# Altium schematic sheet PAGE1-MCU,USB,ADC.SchDoc("PAGE1-MCU,USB,ADC") (continued)

## Library data of the parts
C1 C4 C8 = 100nF: MURATA - GRM188R71C104KA01J - CAP, MLCC, X7R, 0.1UF, 16V, 0603; Voltage Rating: 16; SVHC: No SVHC (20-Jun-2016; Product Range: GRM Series; Dielectric Characteristic: X7; Ceramic Capacitor Case: 0603 [1608 Metric]; Capacitance Tolerance: ± 10%; Capacitance: 0.1; Supplier Part Number 1: 2688519; Supplier 1: Farnell; Stock 1: 18297; RoHS: YES; Pricing 1: 10=0.0145, 100=0.0126, 500=0.0081, 2500=0.0059, 5000=0.0052, 10000=0.0046, 50000=0.0036, 100000=0.0035 (GBP); Packaging: Cut Tape; Operating Temperature Min: -55; Operating Temperature Max: 125; MSL: MSL 1 - Unlimited; Manufacturer Part Number: GRM188R71C104KA01J; Manufacturer: MURATA; Library Name: Capacitors.IntLib; ComponentLink1URL: http://www.farnell.com/datasheets/2189124.pdf; ComponentLink1Description: http://www.farnell.com/datasheets/2189124.pdf; Component Kind: Standard; Automotive Qualification Standard: -
C2 = 4u7  16V 0603: MURATA - GRM188R61C475KAAJD - CAP, MLCC, X5R, 4.7UF, 16V, 0603; Voltage Rating: 16; SVHC: No SVHC (17-Dec-2015)<!--FANDB--><div class="features-and-benefits-container"><div class="features-and-benefits-desc-container"><span class="features-and-benefits-container-desc">SMD Multilayer Ceramic Capacitor, 0603 [1608 Metric], 4.7 µF, 16 V, ± 10%, X5; Product Range: GRM Series; Dielectric Characteristic: X5; Ceramic Capacitor Case: 0603 [1608 Metric]; Capacitance Tolerance: ± 10%; Capacitance: 4.7; Supplier Part Number 1: 2611924; Supplier 1: Farnell; Stock 1: 5038; RoHS: YES; Pricing 1: 10=0.16, 100=0.0929, 500=0.076, 1000=0.0618, 2000=0.0605, 4000=0.0425, 20000=0.0409, 40000=0.0355 (GBP); Packaging: Cut Tape; Operating Temperature Min: -55; Operating Temperature Max: 85; MSL: MSL 1 - Unlimited; Manufacturer Part Number: GRM188R61C475KAAJD; Manufacturer: MURATA; Library Name: Capacitors.IntLib; ComponentLink1URL: http://www.farnell.com/datasheets/2079168.pdf; ComponentLink1Description: http://www.farnell.com/datasheets/2079168.pdf; Component Kind: Standard; Automotive Qualification Standard: -
C3 = 10nF: Cap Ceramic 0.01uF 50V X7R 20% SMD 0805 125C Paper T/R; Manufacturer Part Number: C0805C103M5RAC7800; Manufacturer: KEMET; Voltage: 50 Vdc; Rad Hard: No; Product Length: 2 mm; Product Height: 0.78 mm; Product Depth: 1.25 mm; Tolerance: 20 %; Supplier Part Number 1: 2581081; Supplier 1: Farnell; Package/Case: 0805; Operating Temperature: -55 to 125 °C; Mounting: Surface Mount; Dielectric: X7R; Construction: Flat; ComponentLink1URL: http://capacitoredge.kemet.com/capedge2/DataSheet?pn=C0805C103M5RACTU; ComponentLink1Description: http://capacitoredge.kemet.com/capedge2/DataSheet?pn=C0805C103M5RACTU; Component Kind: Standard; Category: Capacitor Ceramic Multilayer; Capacitance Value: 0.01 uF; Library Name: RPi-DAC-schlib.SchLib
C5 = 10uF /16V: TDK - CGA4J1X5R1C106K125AC - CAP, MLCC, X5R, 10UF, 16V, 0805; Supplier Part Number 1: 2210948RL; Supplier 1: Farnell; Stock 1: 8974; RoHS: YES; Pricing 1: 5=0.41, 50=0.146, 250=0.131, 500=0.12, 1000=0.103 (GBP); Manufacturer Part Number: CGA4J1X5R1C106K125AC; Manufacturer: TDK; Library Name: Capacitors.IntLib; ComponentLink3URL: http://www.farnell.com/datasheets/1683658.pdf; ComponentLink3Description: http://www.farnell.com/datasheets/1683658.pdf; ComponentLink2URL: http://www.farnell.com/datasheets/1936565.pdf; ComponentLink2Description: http://www.farnell.com/datasheets/1936565.pdf; ComponentLink1URL: http://bcove.me/e1v54mtm; ComponentLink1Description: http://bcove.me/e1v54mtm; Component Kind: Standard
C6 = 22u 6.3V 0805: MULTICOMP - MC0805X226M6R3CT - CAP, MLCC, X5R, 22UF, 0805; Voltage Rating: 6.3; SVHC: No SVHC (07-Jul-2017); Product Range: MC Series; Dielectric Characteristic: X5; Ceramic Capacitor Case: 0805 [2012 Metric]; Capacitor Terminals: SMD; Capacitance Tolerance ±: ± 20%; Capacitance: 22; Supplier Part Number 1: 1759415; Supplier 1: Farnell; Stock 1: 74736; RoHS: YES; Pricing 1: 5=0.128, 50=0.0738, 250=0.0507, 500=0.042, 1500=0.0358 (GBP); Packaging: Cut Tape; Operating Temperature Range: -55°C to +85°C<!--FANDB--><div class="features-and-benefits-container"><div class="features-and-benefits-desc-container"><span class="features-and-benefits-container-desc">SMD Multilayer Ceramic Capacitor, 0805 [2012 Metric], 22 µF, 6.3 V, ± 20%, X5R, MC Series</span></div><div class="features-and-benefits-description"><span class="features-benefits-value">The Multicomp MC series is general purpose MLCC capacitors with X5R dielectric material which provides high electrical precision, stability and reliability. This MLCC capacitor consists of a conducting material and electrodes with high density and high efficiency ceramic condensers.</span></div><div class="features-and-benefits-list"><ul class="features-and-benefits-list-list"><li class="features-and-benefits-list-item">Small size with higher capacitance values</li><li class="features-and-benefits-list-item">0201, 0402, 0603, 0805, 1206, 1210, 1812 case sizes available</li><li class="features-and-benefits-list-item">Capacitance value ranging from 100pF to 220?F</li><li class="features-and-benefits-list-item">Capacitor with lead free termination (pure Tin)</li><li class="features-and-benefits-list-item">High electrical precision, stability and reliability</li><li class="features-and-benefits-list-item">Rated voltage of 4V, 6.3V, 10V, 16V, 25V, 50V</li><li class="features-and-benefits-list-item">Operating temperature of -55°C to +85°C</li><li class="features-and-benefits-list-item">Available in ±10% and ±20; Operating Temperature Min: -55; Operating Temperature Max: 85; MSL: -; Manufacturer Part Number: MC0805X226M6R3CT; Manufacturer: MULTICOMP; Library Name: Capacitors.IntLib; ComponentLink2URL: http://www.farnell.com/datasheets/1932399.pdf; ComponentLink2Description: http://www.farnell.com/datasheets/1932399.pdf; ComponentLink1URL: http://www.farnell.com/datasheets/1901289.pdf; ComponentLink1Description: http://www.farnell.com/datasheets/1901289.pdf; Component Kind: Standard; Capacitance Tolerance: ± 20%; Automotive Qualification Standard: -
C7 C9 C10 = 1uF: MURATA - GRM188R61H105KAALD - CAP, MLCC, X5R, 1UF, 50V, 0603; Voltage Rating: 50; SVHC: No SVHC (17-Dec-2015); Product Range: GRM Series; Dielectric Characteristic: X5; Ceramic Capacitor Case: 0603 [1608 Metric]; Capacitor Terminals: SMD<!--FANDB--><div class="features-and-benefits-container"><div class="features-and-benefits-description"><span class="features-benefits-value">The Murata GRM series capacitors are Multilayer Ceramic Chip Capacitors (MLCC) used for general purpose applications. These MLCC capacitors are classified into temperature compensating and high dielectric constant types depending on the change in electrostatic capacitance due to change in temperature. The temperature compensating capacitors feature a small rate of change in electrostatic capacitance as the temperature changes and are used for applications such as filters and high frequency circuit matching. The high dielectric constant capacitors use materials with a high dielectric constant and feature a large electrostatic capacitance. Typical applications are power supply decoupling and smoothing circuits.</span></div><div class="features-and-benefits-list"><ul class="features-and-benefits-list-list"><li class="features-and-benefits-list-item">Large capacity and small size in a multilayer structure</li><li class="features-and-benefits-list-item">Capacitance value ranging from 0.1pF to 220?F</li><li class="features-and-benefits-list-item">Tin plated external electrodes, excellent solderability</li><li class="features-and-benefits-list-item">Provides good high frequency characteristics</li><li class="features-and-benefits-list-item">Excellent pulse response</li><li class="features-and-benefits-list-item">High reliability</li><li class="features-and-benefits-list-item">Capacitor size varies from 0.4×0.2mm to 5.7×5.0mm</li><li class="features-and-benefits-list-item">Rated voltage of 2.5VDC to 3.15; Capacitance Tolerance ±: ± 10%; Capacitance: 1; Supplier Part Number 1: 1845736; Supplier 1: Farnell; Stock 1: 62682; RoHS: YES; Pricing 1: 10=0.093, 100=0.062, 500=0.0507, 1000=0.0465, 2000=0.0429, 4000=0.0399, 20000=0.0372, 40000=0.0365 (GBP); Packaging: Cut Tape; Operating Temperature Min: -55; Operating Temperature Max: 85; MSL: -; Manufacturer Part Number: GRM188R61H105KAALD; Manufacturer: MURATA; Library Name: Capacitors.IntLib; ComponentLink1URL: http://www.farnell.com/datasheets/2048611.pdf; ComponentLink1Description: http://www.farnell.com/datasheets/2048611.pdf; Component Kind: Standard; Capacitance Tolerance: ± 10%; Automotive Qualification Standard: -
C11 = 100pF: MULTICOMP   MCSH18B101K500CT   SMD Multilayer Ceramic Capacitor, MCSH Series, 100 pF,  10%, X7R, 50 V, 0603 [1608 Metric]; Voltage Rating (DC): 50V; Voltage Rating: 50V; Tolerance: 10%; Supplier Part Number 1: 1856366; Supplier 1: Farnell; Stock 1: 9630; REACH SVHC: No SVHC; Pricing 1: 10=0.0858, 100=0.0386, 500=0.0266, 1000=0.0203, 2000=0.0176 (EUR); Packaging: Cut Tape; Min Operating Temperature: -55°C; Max Operating Temperature: 125°C; Manufacturer Part Number: MCSH18B101K500CT; Manufacturer: Multicomp; Library Name: Capacitors.IntLib; Dielectric: X7R; ComponentLink2URL: https://datasheet.ciiva.com/36855/1825494-36855530.pdf; ComponentLink2Description: https://datasheet.ciiva.com/36855/1825494-36855530.pdf; ComponentLink1URL: https://datasheet.ciiva.com/36858/1825494-36858405.pdf; ComponentLink1Description: https://datasheet.ciiva.com/36858/1825494-36858405.pdf; Component Kind: Standard; Case Code (Metric): 1608; Case Code (Imperial): 0603; Case/Package: 0603; Capacitance: 100pF
C12-C15 C17-C20 C23-C26 C28-C36 = 47pF: CAP CER 47PF 50V NP0 0603; Manufacturer Part Number: C0603C470J5GACTU; Manufacturer: KEMET; Tolerance: 5%; Temperature Coefficient: 30ppm/°C; Dielectric Material: Ceramic; Dielectric: C0G; Width: 0.031inch; Voltage Rating (DC): 50V; Voltage Rating: 50V; Voltage: 50V; Thickness: 0.034inch; Termination: SMD/SMT; Supplier Part Number 1: 1414639; Supplier 1: Farnell; Stock 1: 63826; REACH SVHC: No SVHC; Radiation Hardening: No; Pricing 1: 10=0.0233, 100=0.0154, 500=0.0102, 1000=0.0076, 2000=0.0073 (GBP); Packaging: Tape and Reel; Package Quantity: 1; Number of Pins: 2; Mount: Surface Mount,PCB; Min Operating Temperature: -55°C; Max Operating Temperature: 125°C; Library Name: Capacitors.IntLib; Length: 1.6mm; Lead Pitch: 0.7mm; Lead Free: Lead Free; Height: 0.8mm; Depth: 0.8mm; Contact Plating: Tin,Matte; Contact Material: Tin; ComponentLink8URL: https://datasheet.ciiva.com/28668/1789732-28668701.pdf; ComponentLink8Description: https://datasheet.ciiva.com/28668/1789732-28668701.pdf; ComponentLink7URL: https://datasheet.ciiva.com/14589/70095383-14589564.pdf; ComponentLink7Description: https://datasheet.ciiva.com/14589/70095383-14589564.pdf; ComponentLink6URL: https://datasheet.ciiva.com/18193/getdatasheetpartid-120905-18193050.pdf; ComponentLink6Description: https://datasheet.ciiva.com/18193/getdatasheetpartid-120905-18193050.pdf; ComponentLink5URL: https://datasheet.ciiva.com/32432/kem-c1003-c0g-eng-tds-32432423.pdf; ComponentLink5Description: https://datasheet.ciiva.com/32432/kem-c1003-c0g-eng-tds-32432423.pdf; ComponentLink4URL: https://datasheet.ciiva.com/14588/70095383-14588998.pdf; ComponentLink4Description: https://datasheet.ciiva.com/14588/70095383-14588998.pdf; ComponentLink3URL: https://datasheet.ciiva.com/7823/kem-c1003-c0g-smd-7823782.pdf; ComponentLink3Description: https://datasheet.ciiva.com/7823/kem-c1003-c0g-smd-7823782.pdf; ComponentLink2URL: https://datasheet.ciiva.com/7823/kem-c1003-c0g-smd-7823781.pdf; ComponentLink2Description: https://datasheet.ciiva.com/7823/kem-c1003-c0g-smd-7823781.pdf; ComponentLink1URL: https://datasheet.ciiva.com/144/docfinderpartnumber-c0603c470j5gactu-144266.pdf; ComponentLink1Description: https://datasheet.ciiva.com/144/docfinderpartnumber-c0603c470j5gactu-144266.pdf; Component Kind: Standard; Case Code (Metric): 1608; Case Code (Imperial): 0603; Case/Package: 0603; Capacitance: 47pF
C16 C21 C22 C27 = 20pF: MURATA - GRM1885C1H200JA01D - CAPACITOR, MLCC, C0G, 20PF, 50V, 0603; Voltage Rating: 50; SVHC: No SVHC (17-Dec-2015; Product Range: GRM Series; Dielectric Characteristic: C0G / NP0; Ceramic Capacitor Case: 0603 [1608 Metric]; Capacitance Tolerance: ± 5%; Capacitance: 20; Supplier Part Number 1: 2456108; Supplier 1: Farnell; Stock 1: 5812; RoHS: YES; Pricing 1: 10=0.0245, 100=0.0159, 500=0.0123, 1000=0.0095, 2000=0.0093, 4000=0.0073, 20000=0.0062, 40000=0.0057 (GBP); Packaging: Cut Tape; Operating Temperature Min: -55; Operating Temperature Max: 125; MSL: MSL 1 - Unlimited; Manufacturer Part Number: GRM1885C1H200JA01D; Manufacturer: MURATA; Library Name: Capacitors.IntLib; ComponentLink1URL: http://www.farnell.com/datasheets/2048077.pdf; ComponentLink1Description: http://www.farnell.com/datasheets/2048077.pdf; Component Kind: Standard; Automotive Qualification Standard: -
CON1 = CON DB15 FM TH: SOCKET, D, 40-40UNC, 15WAY; Manufacturer Part Number: 3-106506-2; Manufacturer: TE Connectivity; Voltage Rating: 125V; Sealable: No (Without); Preloaded: Yes; Plating: Tin; Pitch: 2.74mm; PCB Thickness: 1.57mm; PCB Mount Retention: With; PCB Mounting Orientation: Right Angle; PCB Mount Alignment: Yes (With); Number of Positions: 15; Number of Ports: 1; Gender: Female; Current Rating: 6A; Connector Type: Receptacle; Body Material: Steel,Metal; Width: 13.6mm; Termination Post Length: 3.2mm; Termination: Solder; Supplier Part Number 1: 3417220; Supplier 1: Farnell; Stock 1: 773; Shell Material: Steel; REACH SVHC: Unknown; Radiation Hardening: No; Pricing 1: 1=3.6, 10=3.17, 50=3.02, 100=2.88, 150=2.49, 250=2.09 (EUR); Packaging: Tray; Package Quantity: 45; Orientation: Right Angle; Number of Terminals: 15; Number of Rows: 2; Number of Contacts: 15; Mount: Through Hole; Min Operating Temperature: -55°C; Max Operating Temperature: 105°C; Mating Retention: Yes (With); Mating Connector Lock: Yes (With); Mating Alignment: Yes (With); Material: Steel; Length: 39.2mm; Housing Material: Polyester; Housing Colour: Black; Flammability Rating: UL94 V-0; ELV: Compliant; Depth: 21.3mm; Contact Plating: Tin,Gold; Contact Material: Bronze; ComponentLink3URL: https://datasheet.ciiva.com/6965/eng-cd-106506-m-6965100.pdf; ComponentLink3Description: https://datasheet.ciiva.com/6965/eng-cd-106506-m-6965100.pdf; ComponentLink2URL: https://datasheet.ciiva.com/10370/eng-cs-82068-amplimite-right-angle-posted-conn-0412-10370986.pdf; ComponentLink2Description: https://datasheet.ciiva.com/10370/eng-cs-82068-amplimite-right-angle-posted-conn-0412-10370986.pdf; ComponentLink1URL: https://datasheet.ciiva.com/11743/0900766b804c485c-11743706.pdf; ComponentLink1Description: https://datasheet.ciiva.com/11743/0900766b804c485c-11743706.pdf; Component Kind: Standard; Colour: Black; Library Name: gliax_ecg_simple.SchLib
D1-D10 D13 D14 = TPD1E10B06DPYR: TVS DIODE 5.5VWM 14VC 2X2SON; Manufacturer: Texas Instruments; Working Voltage: 5.5V; Test Current: 1mA; Power Line Protection: No; Peak Pulse Power: 90W; Peak Pulse Current: 5A; Number of Channels: 1; Min Breakdown Voltage: 6V; Max Reverse Leakage Current: 100nA; Interface: GPIO; ESD Protection: Yes; Element Configuration: Single; Direction: Bidirectional; Clamping Voltage: 14V; Capacitance: 12pF; Width: 0.7mm; Supplier Part Number 1: 2345930; Supplier 1: Farnell; Stock 1: 6208; Reverse Standoff Voltage: 5.5V; REACH SVHC: No SVHC; Radiation Hardening: No; Pricing 1: 1=0.415, 50=0.312, 100=0.117, 250=0.108, 500=0.0992, 1000=0.0902 (EUR); Packaging: Tape and Reel; Package Quantity: 1; Number of Pins: 2; Number of Bidirectional Channels: 1; Min Operating Temperature: -40°C; Max Operating Temperature: 125°C; Manufacturer Part Number: TPD1E10B06DPYR; Length: 1.1mm; Leakage Current: 100nA; Lead Free: Lead Free; Height: 0.35mm; Contact Plating: Gold; ComponentLink7URL: https://datasheet.ciiva.com/6574/tpd1e10b06-6574654.pdf; ComponentLink7Description: https://datasheet.ciiva.com/6574/tpd1e10b06-6574654.pdf; ComponentLink6URL: https://datasheet.ciiva.com/2961/tpd1e10b06-2961246.pdf; ComponentLink6Description: https://datasheet.ciiva.com/2961/tpd1e10b06-2961246.pdf; ComponentLink5URL: https://datasheet.ciiva.com/2961/tpd1e10b06-2961245.pdf; ComponentLink5Description: https://datasheet.ciiva.com/2961/tpd1e10b06-2961245.pdf; ComponentLink4URL: https://datasheet.ciiva.com/22691/1877100-22691786.pdf; ComponentLink4Description: https://datasheet.ciiva.com/22691/1877100-22691786.pdf; ComponentLink3URL: https://datasheet.ciiva.com/14195/tpd1e10b06-14195178.pdf; ComponentLink3Description: https://datasheet.ciiva.com/14195/tpd1e10b06-14195178.pdf; ComponentLink2URL: https://datasheet.ciiva.com/46384/1877100-46384054.pdf; ComponentLink2Description: https://datasheet.ciiva.com/46384/1877100-46384054.pdf; ComponentLink1URL: https://datasheet.ciiva.com/26005/sllseb1b-26005840.pdf; ComponentLink1Description: https://datasheet.ciiva.com/26005/sllseb1b-26005840.pdf; Component Kind: Standard; Case/Package: 0402; Breakdown Voltage: 6V; Library Name: gliax_ecg_simple.SchLib
D11 D12 = LED RED: BROADCOM LIMITED - HSMS-C190 - LED, RED, 10MCD, 626NM; Wavelength Typ: 626; Viewing Angle: 170°; SVHC: No SVHC (17-Dec-2015)<!--FANDB--><div class="features-and-benefits-container"><div class="features-and-benefits-description"><span class="features-benefits-value">The HSMS-C190 is a HER SMD Chip LED with untinted/diffused lens, made of GaP. It is designed in an industry standard package 1.6 x 0.8mm footprint with 0.8mm profile. It is compatible with IR reflow solder processes. The small size and wide viewing angle make this LED prime choices for backlighting applications and front panel illumination especially where space is a premium.</span></div><div class="features-and-benefits-list"><ul class="features-and-benefits-list-list"><li class="features-and-benefits-list-item">Small size</li><li class="features-and-benefits-list-item">Industry standard footprint</li><li class="features-and-benefits-list-item">Compatible with IR solder</li><li class="features-and-benefits-list-item">Diffused optics</li><li class="features-and-benefits-list-item">-40 to 85; Luminous Intensity: 10; Lens Shape: Rectangular; LED Mounting: SMD; Forward Voltage: 2.1; Forward Current If: 20; Supplier Part Number 1: 2497356; Supplier 1: Farnell; Stock 1: 7534; RoHS: YES; Product Range: -; Pricing 1: 1=0.361, 10=0.256, 50=0.235, 100=0.106, 200=0.101 (GBP); Packaging: Cut Tape; MSL: -; Manufacturer Part Number: HSMS-C190; Manufacturer: BROADCOM LIMITED; Library Name: Diodes.IntLib; LED Colour: Red; ComponentLink3URL: http://www.farnell.com/datasheets/2165483.pdf; ComponentLink3Description: http://www.farnell.com/datasheets/2165483.pdf; ComponentLink2URL: http://www.farnell.com/datasheets/1681524.pdf; ComponentLink2Description: http://www.farnell.com/datasheets/1681524.pdf; ComponentLink1URL: http://www.farnell.com/datasheets/313031.pdf; ComponentLink1Description: http://www.farnell.com/datasheets/313031.pdf; Component Kind: Standard; Bulb Size: -; Automotive Qualification Standard: -
FB1-FB12 = BLM15PG100SN1D: Ferrite Beads Multi-Layer 10Ohm 100MHz 1A 50mOhm DCR 0402 T/R; Manufacturer: Murata; Tolerance: 25%; Shielding: Unshielded; Number of Lines: 1; Material: Steel; Impedance: 10R; DC Resistance (DCR): 25mR; Width: 0.5mm; Test Frequency: 100MHz; Termination: SMD/SMT; Supplier Part Number 1: 2443233; Supplier 1: Farnell; Stock 1: 794; REACH SVHC: No SVHC; Pricing 1: 10=0.03, 100=0.0217, 500=0.0163, 2500=0.0122, 5000=0.01, 10000=0.0089, 50000=0.007 (EUR); Packaging: Tape and Reel; Package Quantity: 1; Number of Pins: 2; Number of Circuits: 1; Min Operating Temperature: -55°C; Max Operating Temperature: 125°C; Max DC Current: 1A; Max Current Rating: 1A; Manufacturer Part Number: BLM15PG100SN1D; Length: 1mm; Lead Free: Lead Free; Height: 0.5mm; Depth: 0.55mm; Current Rating: 1A; Core Material: Ferrite; ComponentLink3URL: https://datasheet.ciiva.com/6211/l0110e-6211726.pdf; ComponentLink3Description: https://datasheet.ciiva.com/6211/l0110e-6211726.pdf; ComponentLink2URL: https://datasheet.ciiva.com/46423/1851892-46423898.pdf; ComponentLink2Description: https://datasheet.ciiva.com/46423/1851892-46423898.pdf; ComponentLink1URL: https://datasheet.ciiva.com/41449/001172209-da-01-en-drossel-0402-10r-1-0x0-5mm-10000st-41449496.pdf; ComponentLink1Description: https://datasheet.ciiva.com/41449/001172209-da-01-en-drossel-0402-10r-1-0x0-5mm-10000st-41449496.pdf; Component Kind: Standard; Case Code (Metric): 1005; Case Code (Imperial): 0402; Case/Package: 0402; Library Name: gliax_ecg_simple.SchLib
P1 P2 = Header 2: Header, 2-Pin; Signal Integrity: Connector; Library Name: Miscellaneous Connectors.IntLib; LatestRevisionNote: Re-released for DXP Platform.; LatestRevisionDate: 17-Jul-2002; Publisher: Altium Limited
P3 = Header 4: Header, 4-Pin; Signal Integrity: Connector; Library Name: Miscellaneous Connectors.IntLib; LatestRevisionNote: Re-released for DXP Platform.; LatestRevisionDate: 17-Jul-2002; Publisher: Altium Limited
R1 R10-R12 R17 R48 = 100k: MULTICOMP - MCMR06X104 JTL - RES, CERAMIC, 100K, 5%, 0.1W, 0603; Voltage Rating: 75; Temperature Coefficient: ± 100; Resistor Mounting: Surface Mount Device<!--FANDB--><div class="features-and-benefits-container"><div class="features-and-benefits-description"><span class="features-benefits-value">The Multicomp MCMR series resistors are automotive grade, anti sulphured thick film chip resistor. The resistance range includes E24 and E96 decade values from 1ohm to 10Mohm. These resistors are constructed on top of a high grade ceramic body.</span></div><div class="features-and-benefits-list"><ul class="features-and-benefits-list-list"><li class="features-and-benefits-list-item">EIA 0402, 0603, 0805, 1206 chip sizes available</li><li class="features-and-benefits-list-item">High reliability and stability</li><li class="features-and-benefits-list-item">Automotive grade AEC-Q200 compliant</li><li class="features-and-benefits-list-item">Complaint with (IEC 60068-1) 55/155/56 climatic category</li><li class="features-and-benefits-list-item">Maximum operation voltage of 50V, 75V, 150V and 200V</li><li class="features-and-benefits-list-item">Power rating of 62.5mW, 100mW, 125mW and 250mW</li><li class="features-and-benefits-list-item">Available in ±1% and ±5% tolerance</li><li class="features-and-benefits-list-item">Temperature coefficient (TCR) of +200ppm/°C, +100ppm/°C and -200ppm/°C to +400ppm/°C</li><li class="features-and-benefits-list-item">Operating temperature range -55°C to 155; Resistor Case Style: 0603 [1608 Metric]; Resistance: 100; Product Range: MCMR Series; Supplier Part Number 1: 2073357; Supplier 1: Farnell; Stock 1: 187; RoHS: Y-EX; Resistor Element Material: Ceramic; Resistance Tolerance: ± 5%; Pricing 1: 10=0.0109, 100=0.0085, 500=0.006, 1000=0.0048, 2500=0.004, 5000=0.003, 25000=0.002, 50000=0.0016 (GBP); Power Rating: 100; Packaging: Cut Tape; Manufacturer Part Number: MCMR06X104 JTL; Manufacturer: MULTICOMP; Library Name: Resistors.IntLib; ComponentLink1URL: http://www.farnell.com/datasheets/1716707.pdf; ComponentLink1Description: http://www.farnell.com/datasheets/1716707.pdf; Component Kind: Standard; Automotive Qualification Standard: -
R2 R15 R16 R21 R23 R25 R27 R29 R31 R33 R35 R37 R45 R62 = 10k: MULTICOMP - MCMR06X103 JTL - RES, CERAMIC, 10K, 5%, 0.1W, 0603; Voltage Rating: 75; Temperature Coefficient: ± 100; Resistor Case Style: 0603 [1608 Metric]; Resistance: 10; Product Range: MCMR Series; Power Rating: 100; Automotive Qualification Standard: -<!--FANDB--><div class="features-and-benefits-container"><div class="features-and-benefits-description"><span class="features-benefits-value">The Multicomp MCMR series resistors are automotive grade, anti sulphured thick film chip resistor. The resistance range includes E24 and E96 decade values from 1ohm to 10Mohm. These resistors are constructed on top of a high grade ceramic body.</span></div><div class="features-and-benefits-list"><ul class="features-and-benefits-list-list"><li class="features-and-benefits-list-item">EIA 0402, 0603, 0805, 1206 chip sizes available</li><li class="features-and-benefits-list-item">High reliability and stability</li><li class="features-and-benefits-list-item">Automotive grade AEC-Q200 compliant</li><li class="features-and-benefits-list-item">Complaint with (IEC 60068-1) 55/155/56 climatic category</li><li class="features-and-benefits-list-item">Maximum operation voltage of 50V, 75V, 150V and 200V</li><li class="features-and-benefits-list-item">Power rating of 62.5mW, 100mW, 125mW and 250mW</li><li class="features-and-benefits-list-item">Available in ±1% and ±5% tolerance</li><li class="features-and-benefits-list-item">Temperature coefficient (TCR) of +200ppm/°C, +100ppm/°C and -200ppm/°C to +400ppm/°C</li><li class="features-and-benefits-list-item">Operating temperature range -55°C to 155; Supplier Part Number 1: 2073356; Supplier 1: Farnell; Stock 1: 19791; RoHS: Y-EX; Resistor Element Material: Ceramic; Resistance Tolerance: ± 5%; Pricing 1: 10=0.0087, 100=0.0074, 500=0.0061, 1000=0.0048, 2500=0.0032, 5000=0.0024, 25000=0.0016, 50000=0.0013 (GBP); Packaging: Cut Tape; Manufacturer Part Number: MCMR06X103 JTL; Manufacturer: MULTICOMP; Library Name: Resistors.IntLib; ComponentLink1URL: http://www.farnell.com/datasheets/1716707.pdf; ComponentLink1Description: http://www.farnell.com/datasheets/1716707.pdf; Component Kind: Standard
R3 R5-R9 R13 R14 = 51R: RES SMD 51 OHM 1% 1/10W 0603; Manufacturer Part Number: CRCW060351R0FKEA; Manufacturer: Vishay; Working Voltage: 75V; Temperature Coefficient: 100ppm/°C; Power Rating: 100mW; Width: 0.85mm; Weight: 7.1E-05oz; Voltage Rating (DC): 75V; Voltage Rating: 75V; Tolerance: 1%; Termination: SMD/SMT; Supplier Part Number 1: 1652889; Supplier 1: Farnell; Stock 1: 1083; Resistance: 51R; REACH SVHC: Unknown; Radiation Hardening: No; Pricing 1: 10=0.0116, 250=0.0087, 500=0.0059, 1250=0.0052, 2500=0.0046 (GBP); Packaging: Tape and Reel; Package Quantity: 10; Number of Terminations: 2; Number of Pins: 2; Min Operating Temperature: -55°C; Military Standard: Not; Max Power Dissipation: 100mW; Max Operating Temperature: 155°C; Library Name: Resistors.IntLib; Length: 1.55mm; Lead Free: Lead Free; Height: 0.45mm; Depth: 0.85mm; Contact Plating: Tin; Composition: Thick Film; ComponentLink11URL: https://datasheet.ciiva.com/26316/fld-crcw-e3-data-en-26316559.pdf; ComponentLink11Description: https://datasheet.ciiva.com/26316/fld-crcw-e3-data-en-26316559.pdf; ComponentLink10URL: https://datasheet.ciiva.com/2465/dcrcwe3-2465965.pdf; ComponentLink10Description: https://datasheet.ciiva.com/2465/dcrcwe3-2465965.pdf; ComponentLink9URL: https://datasheet.ciiva.com/2465/dcrcwe3-2465964.pdf; ComponentLink9Description: https://datasheet.ciiva.com/2465/dcrcwe3-2465964.pdf; ComponentLink8URL: https://datasheet.ciiva.com/16763/70201119-16763963.pdf; ComponentLink8Description: https://datasheet.ciiva.com/16763/70201119-16763963.pdf; ComponentLink7URL: https://datasheet.ciiva.com/2465/dcrcwe3-2465963.pdf; ComponentLink7Description: https://datasheet.ciiva.com/2465/dcrcwe3-2465963.pdf; ComponentLink6URL: https://datasheet.ciiva.com/22870/70201119-22870938.pdf; ComponentLink6Description: https://datasheet.ciiva.com/22870/70201119-22870938.pdf; ComponentLink5URL: https://datasheet.ciiva.com/7690/1720486-7690872.pdf; ComponentLink5Description: https://datasheet.ciiva.com/7690/1720486-7690872.pdf; ComponentLink4URL: https://datasheet.ciiva.com/7690/1697337-7690727.pdf; ComponentLink4Description: https://datasheet.ciiva.com/7690/1697337-7690727.pdf; ComponentLink3URL: https://datasheet.ciiva.com/5542/dcrcwe3-109170-5542133.pdf; ComponentLink3Description: https://datasheet.ciiva.com/5542/dcrcwe3-109170-5542133.pdf; ComponentLink2URL: https://datasheet.ciiva.com/3257/dcrcwe3-3257701.pdf; ComponentLink2Description: https://datasheet.ciiva.com/3257/dcrcwe3-3257701.pdf; ComponentLink1URL: https://datasheet.ciiva.com/11809/0900766b80d98afc-11809837.pdf; ComponentLink1Description: https://datasheet.ciiva.com/11809/0900766b80d98afc-11809837.pdf; Component Kind: Standard; Case Code (Metric): 1608; Case Code (Imperial): 0603; Case/Package: 0603
R4 R44 = 0R: BOURNS - CR0603-J/-000ELF - RES, THICK FILM, 0R, 5%, 0.1W, 0603; Voltage Rating: 50; SVHC: No SVHC (17-Dec-2015); Resistor Mounting: Surface Mount Device<!--FANDB--><div class="features-and-benefits-container"><div class="features-and-benefits-description"><span class="features-benefits-value">The Bourns CR series are standard thick film chip resistors. These resistors provide flexibility of performance, space saving and low cost. The Resistance range includes E96 and E24 decade values from 1ohm to 10Mohm.</span></div><div class="features-and-benefits-list"><ul class="features-and-benefits-list-list"><li class="features-and-benefits-list-item">0201, 0402, 0603, 0805, 1206, 1210, 2010, 2512 standard case sizes available</li><li class="features-and-benefits-list-item">±1% and ±5% tolerance</li><li class="features-and-benefits-list-item">±100ppm/°C, ±200ppm/°C and ±250ppm/°C TCR values available</li><li class="features-and-benefits-list-item">Power rating selectable for 50mW, 63mW, 0.1W, 0.125W, 0.25W, 0.5W and 1W based on ordering</li><li class="features-and-benefits-list-item">Jumpers are available for all size</li><li class="features-and-benefits-list-item">3 layer termination process with nickel barrier prevents leaching & provides excellent solderability</li><li class="features-and-benefits-list-item">Suitable for all type of soldering processes</li><li class="features-and-benefits-list-item">Tight dimensional tolerances</li><li class="features-and-benefits-list-item">Maximum working voltage depends on case size</li><li class="features-and-benefits-list-item">Operating temperature of -55°C to +125°C(CR0201) and -55°C to +155; Resistor Case Style: 0603 [1608 Metric]; Resistance: 0; Product Range: CR Series; Power Rating: 100; Temperature Coefficient: -; Supplier Part Number 1: 2008343; Supplier 1: Farnell; Stock 1: 58200; RoHS: YES; Resistor Element Material: Thick Film; Resistance Tolerance: ± 5%; Pricing 1: 10=0.0146, 250=0.0097, 1000=0.0073, 5000=0.0049, 12500=0.0042 (GBP); Packaging: Cut Tape; MSL: MSL 1 - Unlimited; Manufacturer Part Number: CR0603-J/-000ELF; Manufacturer: BOURNS; Library Name: Resistors.IntLib; ComponentLink2URL: http://www.farnell.com/datasheets/2178762.pdf; ComponentLink2Description: http://www.farnell.com/datasheets/2178762.pdf; ComponentLink1URL: http://www.bourns.com/engineering/CHPREZTR/cr0603.stp; ComponentLink1Description: http://www.bourns.com/engineering/CHPREZTR/cr0603.stp; Component Kind: Standard; Automotive Qualification Standard: -
R18 R20 = 1k: MULTICOMP - MCMR06X102 JTL - RES, CERAMIC, 1K, 5%, 0.1W, 0603; Voltage Rating: 75; Temperature Coefficient: ± 100; Resistor Mounting: Surface Mount Device<!--FANDB--><div class="features-and-benefits-container"><div class="features-and-benefits-description"><span class="features-benefits-value">The Multicomp MCMR series resistors are automotive grade, anti sulphured thick film chip resistor. The resistance range includes E24 and E96 decade values from 1ohm to 10Mohm. These resistors are constructed on top of a high grade ceramic body.</span></div><div class="features-and-benefits-list"><ul class="features-and-benefits-list-list"><li class="features-and-benefits-list-item">EIA 0402, 0603, 0805, 1206 chip sizes available</li><li class="features-and-benefits-list-item">High reliability and stability</li><li class="features-and-benefits-list-item">Automotive grade AEC-Q200 compliant</li><li class="features-and-benefits-list-item">Complaint with (IEC 60068-1) 55/155/56 climatic category</li><li class="features-and-benefits-list-item">Maximum operation voltage of 50V, 75V, 150V and 200V</li><li class="features-and-benefits-list-item">Power rating of 62.5mW, 100mW, 125mW and 250mW</li><li class="features-and-benefits-list-item">Available in ±1% and ±5% tolerance</li><li class="features-and-benefits-list-item">Temperature coefficient (TCR) of +200ppm/°C, +100ppm/°C and -200ppm/°C to +400ppm/°C</li><li class="features-and-benefits-list-item">Operating temperature range -55°C to 155; Resistor Case Style: 0603 [1608 Metric]; Resistance: 1; Product Range: MCMR Series; Power Rating: 100; Supplier Part Number 1: 2073354; Supplier 1: Farnell; Stock 1: 18133; RoHS: Y-EX; Resistor Element Material: Ceramic; Resistance Tolerance: ± 5%; Pricing 1: 10=0.0089, 100=0.0076, 500=0.0063, 1000=0.0049, 2500=0.0033, 5000=0.0024, 25000=0.0016, 50000=0.0013 (GBP); Packaging: Cut Tape; Manufacturer Part Number: MCMR06X102 JTL; Manufacturer: MULTICOMP; Library Name: Resistors.IntLib; ComponentLink1URL: http://www.farnell.com/datasheets/1716707.pdf; ComponentLink1Description: http://www.farnell.com/datasheets/1716707.pdf; Component Kind: Standard; Automotive Qualification Standard: -
R19 = 392k: Resistor; Thick Film; Res 392 Kilohms; Pwr-Rtg 0.1 W; Tol 1%; SMT; 0603; Tape & Reel; Manufacturer Part Number: ERJ-3EKF3923V; Manufacturer: Panasonic; Voltage Rating (DC): 50V; Voltage Rating: 75V; Temperature Coefficient: 100ppm/°C; Resistance: 392kR; Power Rating: 100mW; Width: 0.031inch; Tolerance: 1%; Termination: SMD/SMT; Supplier Part Number 1: 2303281; Supplier 1: Farnell; Stock 1: 6940; REACH SVHC: Yes; Radiation Hardening: No; Pricing 1: 10=0.0416, 100=0.0243, 500=0.018, 1000=0.0161, 2500=0.0142 (EUR); Packaging: Tape and Reel; Package Quantity: 5000; Number of Terminations: 2; Mount: Surface Mount; Min Operating Temperature: -55°C; Military Standard: Not; Max Power Dissipation: 100mW; Max Operating Temperature: 155°C; Library Name: Resistors.IntLib; Length: 1.6mm; Lead Free: Lead Free; Height: 0.022inch; Depth: 0.8mm; Contact Plating: Tin; Composition: Thick Film; ComponentLink4URL: https://datasheet.ciiva.com/24069/aoa0000ce2-24069454.pdf; ComponentLink4Description: https://datasheet.ciiva.com/24069/aoa0000ce2-24069454.pdf; ComponentLink3URL: https://datasheet.ciiva.com/30160/aoa0000ce2-30160714.pdf; ComponentLink3Description: https://datasheet.ciiva.com/30160/aoa0000ce2-30160714.pdf; ComponentLink2URL: https://datasheet.ciiva.com/16772/70260748-16772474.pdf; ComponentLink2Description: https://datasheet.ciiva.com/16772/70260748-16772474.pdf; ComponentLink1URL: https://datasheet.ciiva.com/9117/aoa0000ce2-9117256.pdf; ComponentLink1Description: https://datasheet.ciiva.com/9117/aoa0000ce2-9117256.pdf; Component Kind: Standard; Case Code (Metric): 1608; Case Code (Imperial): 0603; Case/Package: 0603
R22 R24 R26 R28 R30 R32 R34 R36 R38-R42 R46 R47 = 470R: MULTICOMP - MCMR06X471 JTL - RES, CERAMIC, 470R, 5%, 0.1W, 0603; Supplier Part Number 1: 2073513; Supplier 1: Farnell; Stock 1: 3786; RoHS: Y-EX; Pricing 1: 10=0.0089, 100=0.0076, 500=0.0063, 1000=0.0049, 2500=0.0033, 5000=0.0024, 25000=0.0016, 50000=0.0013 (GBP); Manufacturer Part Number: MCMR06X471 JTL; Manufacturer: MULTICOMP; Library Name: Resistors.IntLib; ComponentLink1URL: http://www.farnell.com/datasheets/1716707.pdf; ComponentLink1Description: http://www.farnell.com/datasheets/1716707.pdf; Component Kind: Standard
R43 = 47k: MULTICOMP - MCMR06X4702FTL - RES, CERAMIC, 47K, 1%, 0.1W, 0603; Voltage Rating: 75; Temperature Coefficient: ± 100; Resistor Case Style: 0603 [1608 Metric]; Resistance: 47; Product Range: MCMR Series; Power Rating: 100; Automotive Qualification Standard: -<!--FANDB--><div class="features-and-benefits-container"><div class="features-and-benefits-description"><span class="features-benefits-value">The Multicomp MCMR series resistors are automotive grade, anti sulphured thick film chip resistor. The resistance range includes E24 and E96 decade values from 1ohm to 10Mohm. These resistors are constructed on top of a high grade ceramic body.</span></div><div class="features-and-benefits-list"><ul class="features-and-benefits-list-list"><li class="features-and-benefits-list-item">EIA 0402, 0603, 0805, 1206 chip sizes available</li><li class="features-and-benefits-list-item">High reliability and stability</li><li class="features-and-benefits-list-item">Automotive grade AEC-Q200 compliant</li><li class="features-and-benefits-list-item">Complaint with (IEC 60068-1) 55/155/56 climatic category</li><li class="features-and-benefits-list-item">Maximum operation voltage of 50V, 75V, 150V and 200V</li><li class="features-and-benefits-list-item">Power rating of 62.5mW, 100mW, 125mW and 250mW</li><li class="features-and-benefits-list-item">Available in ±1% and ±5% tolerance</li><li class="features-and-benefits-list-item">Temperature coefficient (TCR) of +200ppm/°C, +100ppm/°C and -200ppm/°C to +400ppm/°C</li><li class="features-and-benefits-list-item">Operating temperature range -55°C to 155; Supplier Part Number 1: 2073510; Supplier 1: Farnell; Stock 1: 21427; RoHS: Y-EX; Resistor Element Material: Ceramic; Resistance Tolerance: ± 1%; Pricing 1: 10=0.0115, 100=0.009, 500=0.0064, 1000=0.0051, 2500=0.0042, 5000=0.0032, 25000=0.0021, 50000=0.0017 (GBP); Packaging: Cut Tape; Manufacturer Part Number: MCMR06X4702FTL; Manufacturer: MULTICOMP; Library Name: Resistors.IntLib; ComponentLink1URL: http://www.farnell.com/datasheets/1716707.pdf; ComponentLink1Description: http://www.farnell.com/datasheets/1716707.pdf; Component Kind: Standard
SW1 = PTS645VH58-2LFS: SWITCH TACTILE SPST-NO 0.05A 12V; Manufacturer: ITT C&K; Throw Configuration: SPST; Switch Function: Off-Mom; Operating Force: 200gf; Mechanical Life: 100000Cycles; Max Current Rating: 50mA; Illumination Type: Non-Illuminated,Illuminated; Actuator Material: Nylon,Polyamide; Voltage Rating (DC): 12V; Termination: PC Pins; Supplier Part Number 1: 2320088; Supplier 1: Farnell; Stock 1: 7080; REACH SVHC: No SVHC; Pricing 1: 1=0.189, 10=0.175, 50=0.165, 100=0.141, 300=0.135, 700=0.107, 2000=0.105, 7000=0.103 (GBP); Packaging: Bulk; Package Quantity: 1000; Orientation: Right Angle; Mount: Through Hole; Min Operating Temperature: -20°C; Max Operating Temperature: 60°C; Manufacturer Part Number: PTS645VH58-2LFS; Length: 8.5mm; Lead Free: Lead Free; Depth: 9.8mm; Current Rating: 50mA; Contact Plating: Silver; Contact Material: Brass,Silver; ComponentLink2URL: https://datasheet.ciiva.com/22637/1707731-22637360.pdf; ComponentLink2Description: https://datasheet.ciiva.com/22637/1707731-22637360.pdf; ComponentLink1URL: https://datasheet.ciiva.com/29903/getdatasheetpartid-780336-29903805.pdf; ComponentLink1Description: https://datasheet.ciiva.com/29903/getdatasheetpartid-780336-29903805.pdf; Component Kind: Standard; Colour: Black; Library Name: pulseox-main-SCHLib.SchLib
U1 = STM32F411RCT6: STMICROELECTRONICS         STM32F411RCT6             32 Bit Microcontroller, Batch Acquisition Mode, ARM Cortex-M4, 100 MHz, 256 KB, 128 KB, 64 Pins; Watchdog Timer: Yes; RAM Size: 128KB; Peripherals: Brown-out Detect/Reset,POR,PWM,WDT,DMA; Oscillator Type: Internal; Operating Supply Voltage: 3.3V; Number of Timers/Counters: 8; Number of Programmable I/O: 50; Number of ADC Channels: 16; Min Supply Voltage: 1.7V; Memory Type: FLASH; Memory Size: 256KB; Max Supply Voltage: 3.6V; Max Power Dissipation: 313mW; Max Frequency: 100MHz; Interface: I2C,SPI,USB,I2S,IrDA,MMC,SDIO,SD,UART,USART,LIN; Data Bus Width: 32b; Width: 10mm; Weight: 0.012088oz; Supplier Part Number 1: 2456966; Supplier 1: Farnell; Stock 1: 978; REACH SVHC: No SVHC; Radiation Hardening: No; Pricing 1: 1=6.01, 10=4.67, 25=4.45, 50=4.22, 100=4, 250=3.85, 500=2.88 (EUR); Packaging: Tray; Package Quantity: 960; Number of Pins: 64; Number of I/Os: 50; Mount: Surface Mount; Min Operating Temperature: -40°C; Max Operating Temperature: 85°C; Manufacturer Part Number: STM32F411RCT6; Manufacturer: STMicroelectronics; Library Name: SMT_MCU.SchLib; Length: 10mm; Lead Free: Lead Free; Frequency: 100MHz; Core Architecture: ARM; ComponentLink1URL: https://datasheet.ciiva.com/48494/dm00115249-48494030.pdf; ComponentLink1Description: https://datasheet.ciiva.com/48494/dm00115249-48494030.pdf; Component Kind: Standard; Case/Package: LQFP
U2 U4 = TS5A3159MDBVREP: Manufacturer: Texas Instruments; Turn-On Delay Time: 35ns; Turn-Off Delay Time: 20ns; Throw Configuration: SPDT; Supply Type: Single; Operating Supply Current: 100nA; On-State Resistance: 1.1R; Number of Outputs: 2; Number of Inputs: 1; Min Supply Voltage: 1.65V; Max Supply Voltage: 5.5V; Bandwidth: 100MHz; Weight: 0.000229oz; Supplier Part Number 1: 2459821; Supplier 1: Farnell; Stock 1: 2959; REACH SVHC: No SVHC; Radiation Hardening: No; Pricing 1: 1=1.72, 25=1.46, 50=1.32, 100=1.17, 250=1.1, 500=1.02 (EUR); Packaging: Tape and Reel; Package Quantity: 3000; Number of Pins: 6; Number of Channels: 1; Mount: Surface Mount; Min Operating Temperature: -55°C; Max Supply Current: 100nA; Max Operating Temperature: 125°C; Manufacturer Part Number: TS5A3159MDBVREP; Lead Free: Lead Free; ComponentLink6URL: https://datasheet.ciiva.com/1672/ts5a3159-ep-1672102.pdf; ComponentLink6Description: https://datasheet.ciiva.com/1672/ts5a3159-ep-1672102.pdf; ComponentLink5URL: https://datasheet.ciiva.com/1672/ts5a3159-ep-1672101.pdf; ComponentLink5Description: https://datasheet.ciiva.com/1672/ts5a3159-ep-1672101.pdf; ComponentLink4URL: https://datasheet.ciiva.com/41461/001187279-da-01-en-ic-switch-s-ts5a3159mdbvrep-sot-23-6-tid-41461728.pdf; ComponentLink4Description: https://datasheet.ciiva.com/41461/001187279-da-01-en-ic-switch-s-ts5a3159mdbvrep-sot-23-6-tid-41461728.pdf; ComponentLink3URL: https://datasheet.ciiva.com/1672/ts5a3159-ep-1672100.pdf; ComponentLink3Description: https://datasheet.ciiva.com/1672/ts5a3159-ep-1672100.pdf; ComponentLink2URL: https://datasheet.ciiva.com/14435/ts5a3159-ep-14435168.pdf; ComponentLink2Description: https://datasheet.ciiva.com/14435/ts5a3159-ep-14435168.pdf; ComponentLink1URL: https://datasheet.ciiva.com/4918/scds217b-126734-4918496.pdf; ComponentLink1Description: https://datasheet.ciiva.com/4918/scds217b-126734-4918496.pdf; Component Kind: Standard; Case/Package: SOT-23-6; Library Name: gliax_ecg_simple.SchLib
U3 = ADS1298IPAG: TEXAS INSTRUMENTS   ADS1298IPAG.   ANALOG FRONT END, 24 BIT, 100KHZ, TQFP-64; Signal to Noise Ratio (SNR): 112dB; Sampling Rate: 32KS/s; Resolution: 24b; Power Consumption: 6mW; Operating Supply Current: 3.1mA; Number of Inputs: 8; Number of Bits: 24; Min Supply Voltage (DC): 1.65V; Min Supply Voltage: 2.7V; Max Supply Voltage (DC): 3.6V; Max Supply Voltage: 5.25V; Max Power Dissipation: 8.8mW; Interface: SPI; Width: 10.2mm; Type: General Purpose; Supplier Part Number 1: 2323669; Supplier 1: Farnell; Stock 1: 268; REACH SVHC: No SVHC; Radiation Hardening: No; Pricing 1: 1=32.95, 5=31.83, 10=30.27, 25=30.11, 50=26.46 (GBP); Packaging: Tray; Package Quantity: 160; Number of Pins: 64; Number of Converters: 8; Number of Channels: 8; Number of A/D Converters: 8; Min Operating Temperature: -40°C; Max Operating Temperature: 85°C; Manufacturer Part Number: ADS1298IPAG; Manufacturer: Texas Instruments; Length: 10.2mm; Lead Free: Lead Free; Height: 1.05mm; Conversion Rate: 32KS/s; Contact Plating: Gold; ComponentLink4URL: https://datasheet.ciiva.com/25910/ads1294-25910079.pdf; ComponentLink4Description: https://datasheet.ciiva.com/25910/ads1294-25910079.pdf; ComponentLink3URL: https://datasheet.ciiva.com/1168/1796012-1168139.pdf; ComponentLink3Description: https://datasheet.ciiva.com/1168/1796012-1168139.pdf; ComponentLink2URL: https://datasheet.ciiva.com/26796/ads1298ipag-26796374.pdf; ComponentLink2Description: https://datasheet.ciiva.com/26796/ads1298ipag-26796374.pdf; ComponentLink1URL: https://datasheet.ciiva.com/22621/1716507-22621445.pdf; ComponentLink1Description: https://datasheet.ciiva.com/22621/1716507-22621445.pdf; Component Kind: Standard; Case/Package: TQFP; Library Name: TI_ADS_ECG.IntLib
U5 = OPA379AIDBVT: IC OPAMP GP 90KHZ RRO SOT23-5; Manufacturer: Texas Instruments; Voltage Gain: 120dB; Slew Rate: 0.03V/µs; Power Supply Rejection Ratio (PSRR): 113.98dB; Output Current per Channel: 5mA; Operating Supply Current: 2.9uA; Number of Elements: 1; Nominal Supply Current: 5.5uA; Min Supply Voltage: 1.8V; Max Supply Voltage: 5.5V; Max I/O Voltage: 1.5mV; Input Bias Current: 50pA; Common Mode Rejection Ratio: 90dB; Width: 1.6mm; Unity Gain Bandwidth Product: 90kHz; Supplier Part Number 1: 1624412; Supplier 1: Farnell; Stock 1: 215; REACH SVHC: No SVHC; Radiation Hardening: No; Pricing 1: 1=1.09, 25=0.954, 50=0.841, 100=0.728, 250=0.686, 500=0.644, 1000=0.509, 2500=0.502 (EUR); Packaging: Tape and Reel; Package Quantity: 250; Number of Pins: 5; Number of Circuits: 1; Number of Channels: 1; Number of Amplifiers: 1; Nominal Gain Bandwidth Product: 90kHz; Min Operating Temperature: -40°C; Max Operating Temperature: 125°C; Manufacturer Part Number: OPA379AIDBVT; Library Name: OPAMP.IntLib; Length: 2.9mm; Lead Free: Lead Free; Input Offset Voltage (Vos): 1.5mV; Height: 1.15mm; Gain Bandwidth Product: 90kHz; ComponentLink7URL: https://datasheet.ciiva.com/7219/1862482-7219983.pdf; ComponentLink7Description: https://datasheet.ciiva.com/7219/1862482-7219983.pdf; ComponentLink6URL: https://datasheet.ciiva.com/7848/1848965-7848204.pdf; ComponentLink6Description: https://datasheet.ciiva.com/7848/1848965-7848204.pdf; ComponentLink5URL: https://datasheet.ciiva.com/1798/opa379-1798314.pdf; ComponentLink5Description: https://datasheet.ciiva.com/1798/opa379-1798314.pdf; ComponentLink4URL: https://datasheet.ciiva.com/1798/opa379-1798313.pdf; ComponentLink4Description: https://datasheet.ciiva.com/1798/opa379-1798313.pdf; ComponentLink3URL: https://datasheet.ciiva.com/1798/opa379-1798312.pdf; ComponentLink3Description: https://datasheet.ciiva.com/1798/opa379-1798312.pdf; ComponentLink2URL: https://datasheet.ciiva.com/14456/sbos347d-14456374.pdf; ComponentLink2Description: https://datasheet.ciiva.com/14456/sbos347d-14456374.pdf; ComponentLink1URL: https://datasheet.ciiva.com/22700/1830903-22700334.pdf; ComponentLink1Description: https://datasheet.ciiva.com/22700/1830903-22700334.pdf; Component Kind: Standard; Case/Package: SOT-23; Bandwidth: 90kHz
X1 = ABS06-107-32.768KHZ-T: 32.768KHz 4pF Max. ESR 81 Kohms; Manufacturer: Abracon; Tolerance: 20ppm; Series Resistance: 80kR; Frequency Stability: 175ppm; ESR (Equivalent Series Resistance): 60kR; Width: 0.047inch; Termination: SMD/SMT; Supplier Part Number 1: 2467860; Supplier 1: Farnell; Stock 1: 7053; REACH SVHC: No SVHC; Radiation Hardening: No; Pricing 1: 5=0.733, 50=0.59, 250=0.583, 500=0.582, 1500=0.581, 3000=0.58, 15000=0.579 (EUR); Packaging: Tape and Reel; Package Quantity: 3000; Number of Pins: 2; Mount: Surface Mount; Min Operating Temperature: -40°C; Max Operating Temperature: 85°C; Manufacturer Part Number: ABS06-107-32.768KHZ-T; Load Capacitance: 4pF; Library Name: Crystals.SchLib; Length: 0.079inch; Lead Free: Lead Free; Height: 0.024inch; Frequency Tolerance: 20ppm; Frequency: 32.768kHz; ComponentLink4URL: https://datasheet.ciiva.com/29630/abs06-107-32-768khz-t-29630939.pdf; ComponentLink4Description: https://datasheet.ciiva.com/29630/abs06-107-32-768khz-t-29630939.pdf; ComponentLink3URL: https://datasheet.ciiva.com/29630/abs06-107-32-768khz-t-29630938.pdf; ComponentLink3Description: https://datasheet.ciiva.com/29630/abs06-107-32-768khz-t-29630938.pdf; ComponentLink2URL: https://datasheet.ciiva.com/24028/abs06-107-32-768khz-t-24028377.pdf; ComponentLink2Description: https://datasheet.ciiva.com/24028/abs06-107-32-768khz-t-24028377.pdf; ComponentLink1URL: https://datasheet.ciiva.com/23910/1759010-23910051.pdf; ComponentLink1Description: https://datasheet.ciiva.com/23910/1759010-23910051.pdf; Component Kind: Standard; Case Code (Metric): 2012; Case Code (Imperial): 0805; Case/Package: 0805
Y1 = ABM7-10.000MHZ-D2Y-T: Crystal 10MHz 18pF 2-Pin SMD T/R; Manufacturer: Abracon; Series Resistance: 60 Ohm; Mode of Oscillation: Fundamental; Frequency Tolerance: ±20 ppm; Frequency Stability: ±30 ppm; Frequency Aging: ±5(1st) ppm/Year; Type: Crystal; Supplier Part Number 1: 2849434; Supplier 1: Farnell; Standard Frequency: 10 MHz; Package: 2SMD; Mounting: Surface Mount; Minimum Operating Temperature: -40 °C; Maximum Operating Temperature: 85 °C; Manufacturer Part Number: ABM7-10.000MHZ-D2Y-T; Load Capacitance: 18 pF; ComponentLink1URL: https://datasheet.ciiva.com/16397/abm7-16397372.pdf; ComponentLink1Description: https://datasheet.ciiva.com/16397/abm7-16397372.pdf; Component Kind: Standard; Category: Crystals; Library Name: gliax_ecg_simple.SchLib
